# Altium schematic sheet BUFFERS.SchDoc(BUFFERS)
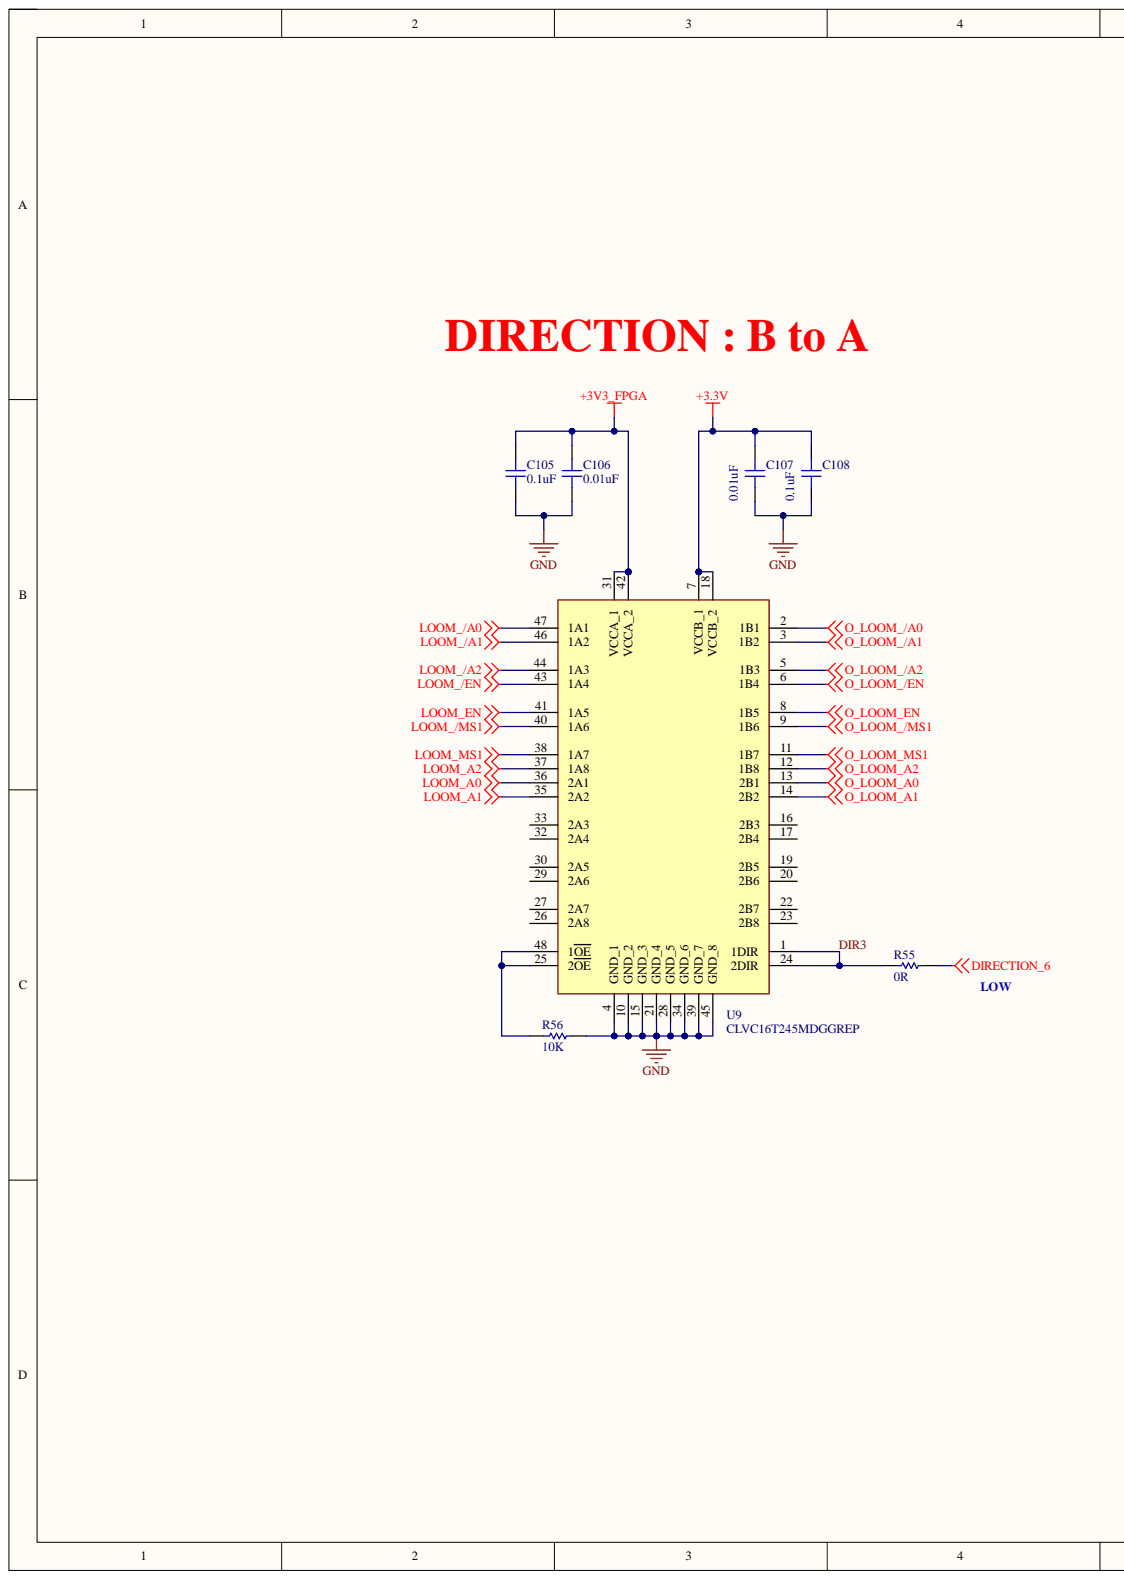
[diagram p0: BUFFERS.SchDoc, left]
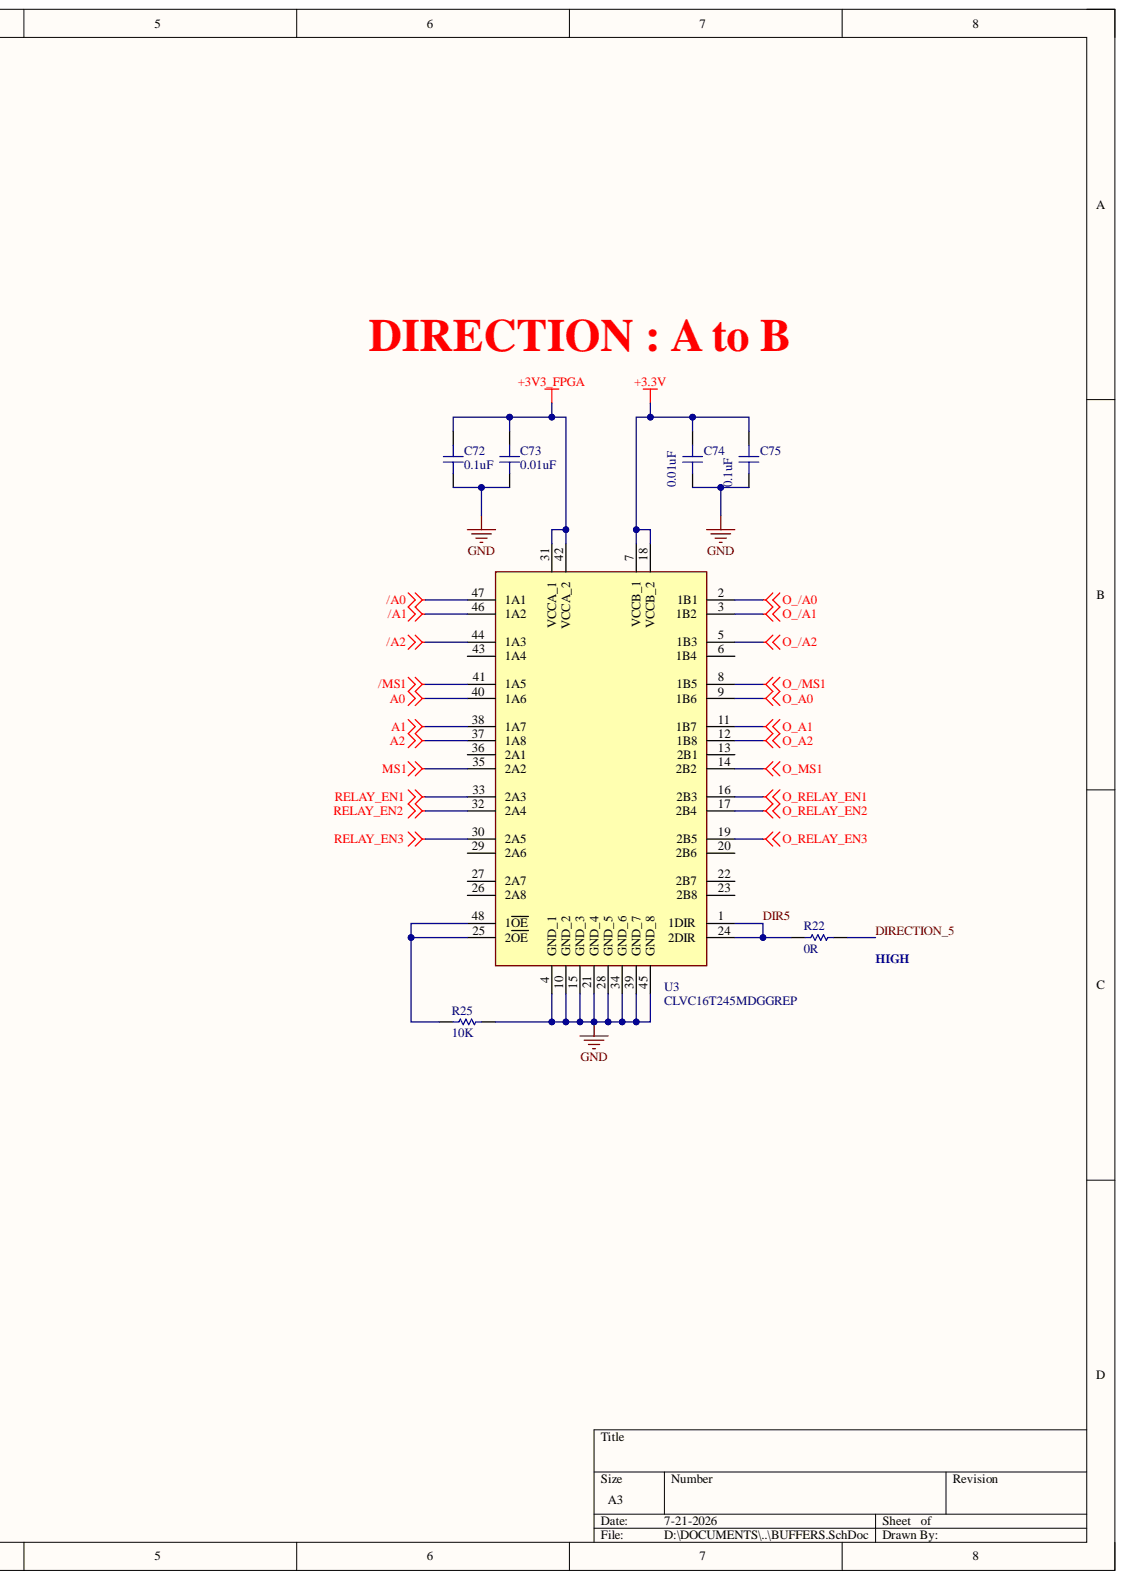
[diagram p1: BUFFERS.SchDoc, right]

## Parts
C72  0.1uF  [CAP_0402]
C73  0.01uF  [CAP_0402]
C74  0.01uF  [CAP_0402]
C75  0.1uF  [CAP_0402]
C105  0.1uF  [CAP_0402]
C106  0.01uF  [CAP_0402]
C107  0.01uF  [CAP_0402]
C108  0.1uF  [CAP_0402]
R22  0R  [RES_0402]
R25  10K  [RES_0402]
R55  0R  [RES_0402]
R56  10K  [RES_0402]
U3  CLVC16T245MDGGREP  [SOP50P810X120-48N]
U9  CLVC16T245MDGGREP  [SOP50P810X120-48N]

## Nets
+3.3V: C74.2 C75.2 C107.2 C108.2 U3.7(VCCB_1) U3.18(VCCB_2) U9.7(VCCB_1) U9.18(VCCB_2)
+3V3_FPGA: C72.2 C73.2 C105.2 C106.2 U3.31(VCCA_1) U3.42(VCCA_2) U9.31(VCCA_1) U9.42(VCCA_2)
/A0: U3.47(1A1)
/A1: U3.46(1A2)
/A2: U3.44(1A3)
/MS1: U3.41(1A5)
A0: U3.40(1A6)
A1: U3.38(1A7)
A2: U3.37(1A8)
DIRECTION_6: R55.2
GND: C72.1 C73.1 C74.1 C75.1 C105.1 C106.1 C107.1 C108.1 R25.1 R56.1 U3.4(GND_1) U3.10(GND_2) U3.15(GND_3) U3.21(GND_4) U3.28(GND_5) U3.34(GND_6) U3.39(GND_7) U3.45(GND_8) U9.4(GND_1) U9.10(GND_2) U9.15(GND_3) U9.21(GND_4) U9.28(GND_5) U9.34(GND_6) U9.39(GND_7) U9.45(GND_8)
LOOM_/A0: U9.47(1A1)
LOOM_/A1: U9.46(1A2)
LOOM_/A2: U9.44(1A3)
LOOM_/EN: U9.43(1A4)
LOOM_/MS1: U9.40(1A6)
LOOM_A0: U9.36(2A1)
LOOM_A1: U9.35(2A2)
LOOM_A2: U9.37(1A8)
LOOM_EN: U9.41(1A5)
LOOM_MS1: U9.38(1A7)
MS1: U3.35(2A2)
O_/A0: U3.2(1B1)
O_/A1: U3.3(1B2)
O_/A2: U3.5(1B3)
O_/MS1: U3.8(1B5)
O_A0: U3.9(1B6)
O_A1: U3.11(1B7)
O_A2: U3.12(1B8)
O_LOOM_/A0: U9.2(1B1)
O_LOOM_/A1: U9.3(1B2)
O_LOOM_/A2: U9.5(1B3)
O_LOOM_/EN: U9.6(1B4)
O_LOOM_/MS1: U9.9(1B6)
O_LOOM_A0: U9.13(2B1)
O_LOOM_A1: U9.14(2B2)
O_LOOM_A2: U9.12(1B8)
O_LOOM_EN: U9.8(1B5)
O_LOOM_MS1: U9.11(1B7)
O_MS1: U3.14(2B2)
O_RELAY_EN1: U3.16(2B3)
O_RELAY_EN2: U3.17(2B4)
O_RELAY_EN3: U3.19(2B5)
RELAY_EN1: U3.33(2A3)
RELAY_EN2: U3.32(2A4)
RELAY_EN3: U3.30(2A5)
DIR3: R55.1 U9.1(1DIR) U9.24(2DIR)
DIR5: R22.1 U3.1(1DIR) U3.24(2DIR)
DIRECTION_5: R22.2
NetR25_2: R25.2 U3.25(2OE) U3.48(1OE)
NetR56_2: R56.2 U9.25(2OE) U9.48(1OE)

Not connected: U3 6(1B4) 13(2B1) 20(2B6) 22(2B7) 23(2B8) 26(2A8) 27(2A7) 29(2A6) 36(2A1) 43(1A4); U9 16(2B3) 17(2B4) 19(2B5) 20(2B6) 22(2B7) 23(2B8) 26(2A8) 27(2A7) 29(2A6) 30(2A5) 32(2A4) 33(2A3)

## Library data of the parts
C72-C75 C105-C108 = CAP_0402_50V: C0402_50V; Component Kind: Standard; Library Name: FPGA_LIB.SchLib
R22 R25 R55 R56 = RES_0402: R0402; Component Kind: Standard; Library Name: FPGA_LIB.SchLib
U3 U9 = CLVC16T245MDGGREP: IC; Manufacturer_Name: Texas Instruments; Component Kind: Standard; Datasheet Link: http://www.ti.com/lit/gpn/sn74lvc16t245-ep; Height: 1.2mm; Library Name: FPGA_LIB.SchLib; Manufacturer_Part_Number: CLVC16T245MDGGREP; Mouser Part Number: 595-CLC16T245MDGGREP; Mouser Price/Stock: https://www.mouser.co.uk/ProductDetail/Texas-Instruments/CLVC16T245MDGGREP?qs=c1nyi2h1TsquyUDOPWgPnw%3D%3D
